# Altium schematic sheet Extensometer_Interface.SchDoc(Extensometer_Interface)
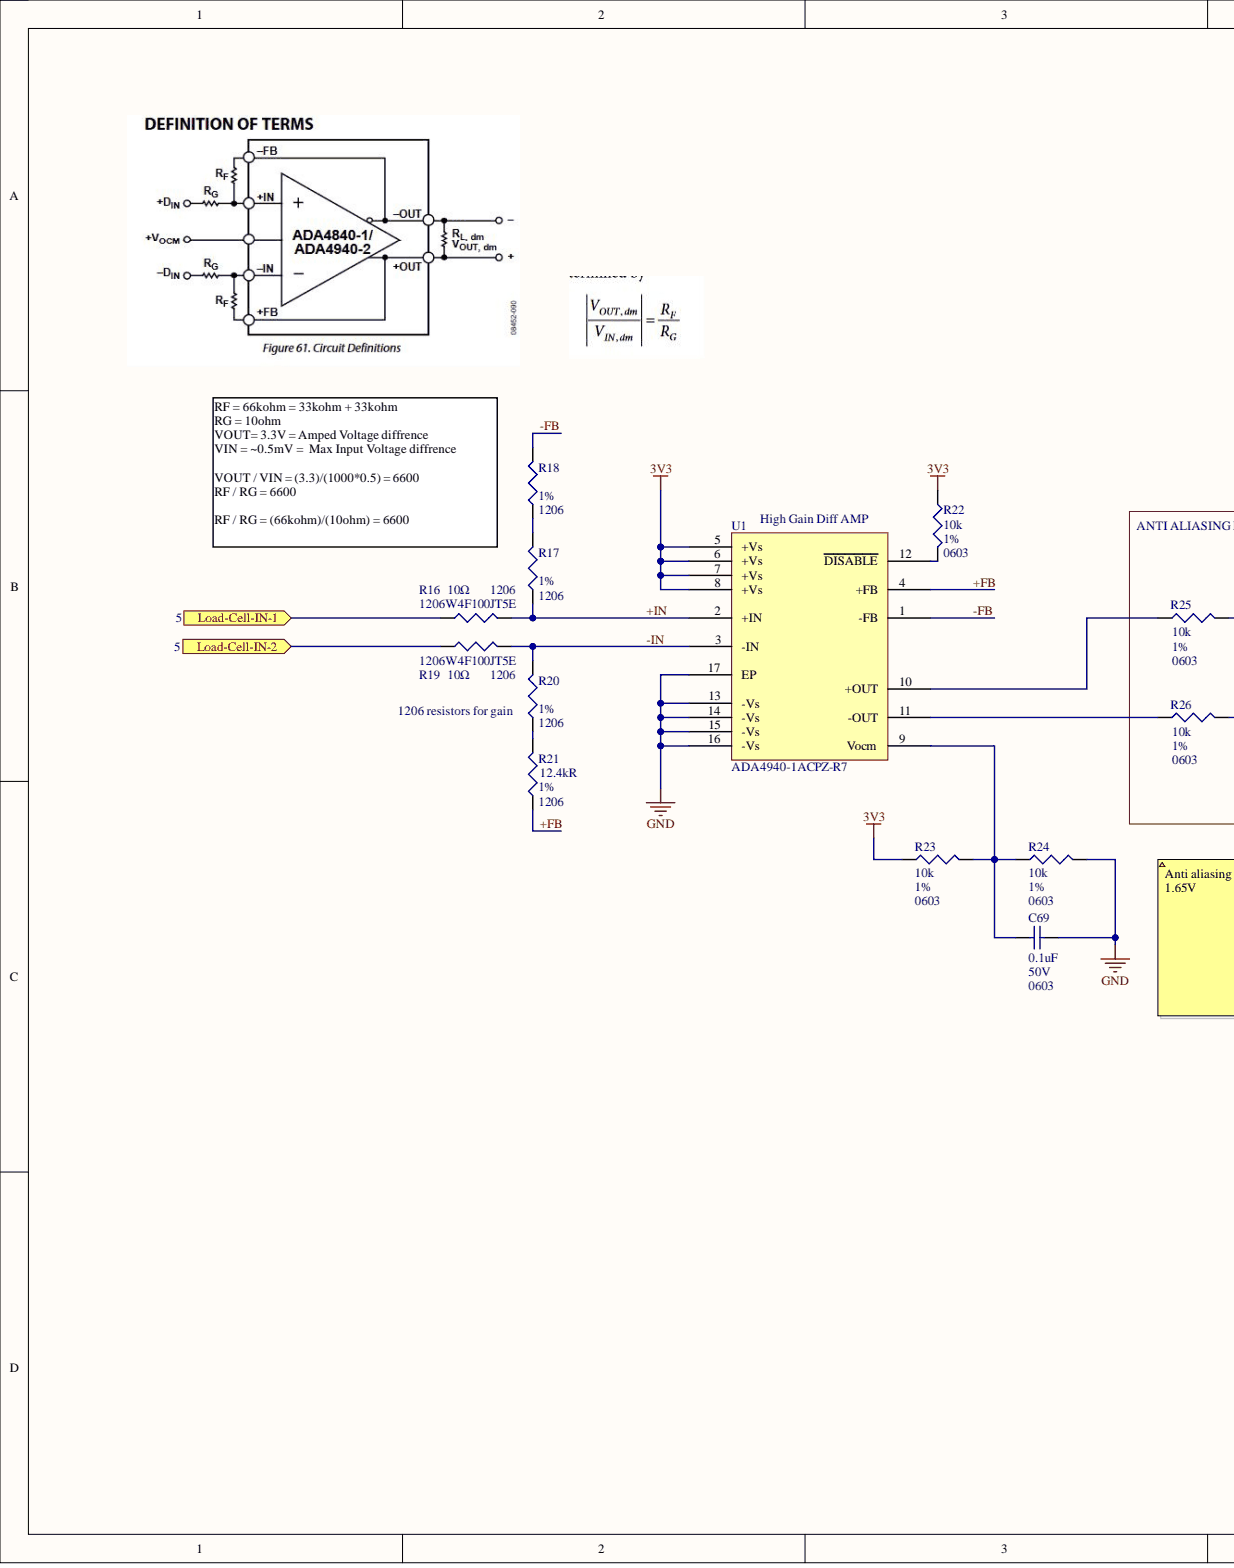
[diagram p0: Extensometer_Interface.SchDoc, left]
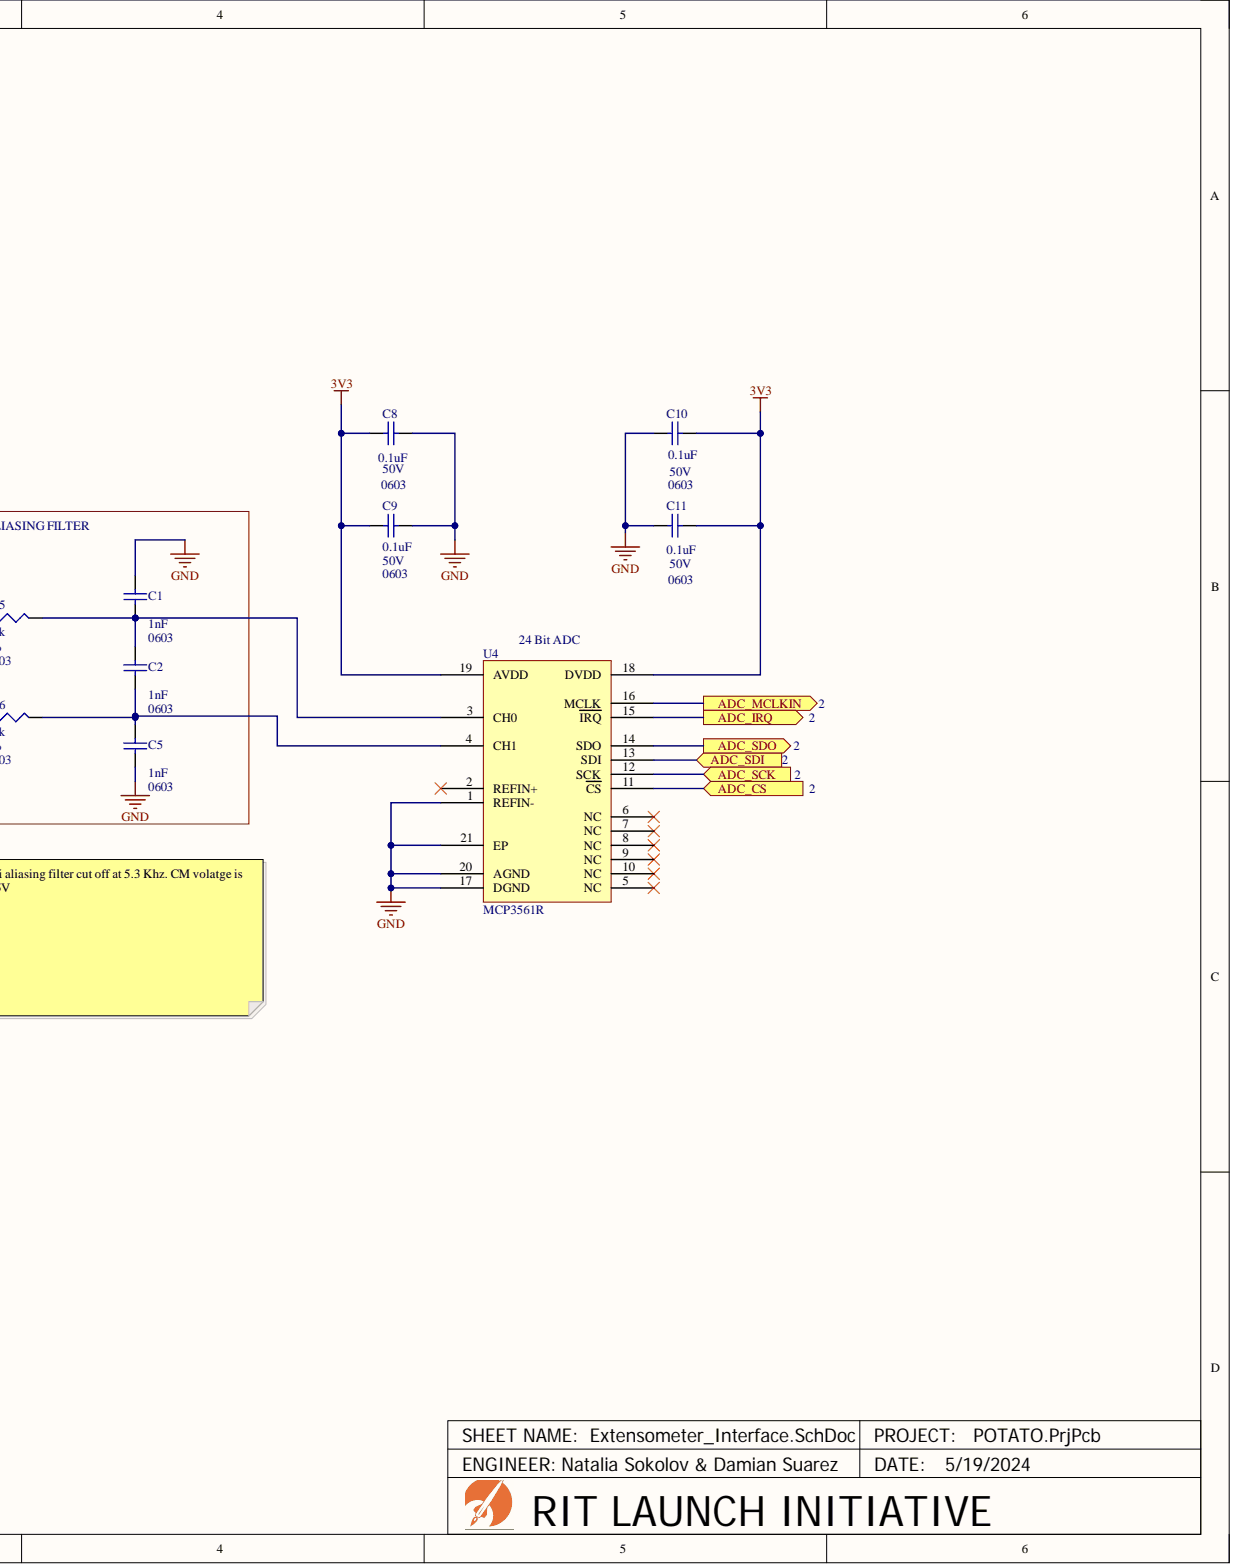
[diagram p1: Extensometer_Interface.SchDoc, right]

## Parts
C1  Capacitance: 1nF  (hidden comment: CL10B102KB8NNNC)
C2  Capacitance: 1nF  Case/Package: 0603  (hidden comment: CL10B102KB8NNNC)
C5  Capacitance: 1nF  Case/Package: 0603  (hidden comment: CL10B102KB8NNNC)
C8  Capacitance: 0.1uF  Voltage: 50V  (hidden comment: CC0603KRX7R9BB104)
C9  Capacitance: 0.1uF  Case Code (Imperial): 0603  Voltage: 50V  (hidden comment: CC0603KRX7R9BB104)
C10  Capacitance: 0.1uF  Voltage: 50V  (hidden comment: CC0603KRX7R9BB104)
C11  Capacitance: 0.1uF  Case Code (Imperial): 0603  Voltage: 50V  (hidden comment: CC0603KRX7R9BB104)
C69  Capacitance: 0.1uF  Case Code (Imperial): 0603  Voltage: 50V  (hidden comment: CC0603KRX7R9BB104)
R16  1206W4F100JT5E  Resistance: 10Ω
R17  Case/Package: 1206  Tolerance: 1%  (hidden comment: RNCP1206FTD12K4)
R18  Case/Package: 1206  Tolerance: 1%  (hidden comment: RNCP1206FTD12K4)
R19  1206W4F100JT5E  Case/Package: 1206  Resistance: 10Ω
R20  Case/Package: 1206  Tolerance: 1%  (hidden comment: RNCP1206FTD12K4)
R21  value 12.4kR  Case/Package: 1206  Tolerance: 1%  (hidden comment: RNCP1206FTD12K4)
R22  Case/Package: 0603  Resistance: 10k  Tolerance: 1%  (hidden comment: 0603WAF1002T5E)
R23  Case/Package: 0603  Resistance: 10k  Tolerance: 1%  (hidden comment: 0603WAF1002T5E)
R24  Resistance: 10k  Tolerance: 1%  (hidden comment: 0603WAF1002T5E)
R25  Case/Package: 0603  Resistance: 10k  Tolerance: 1%  (hidden comment: 0603WAF1002T5E)
R26  Case/Package: 0603  Resistance: 10k  Tolerance: 1%  (hidden comment: 0603WAF1002T5E)
U1  ADA4940-1ACPZ-R7
U4  MCP3561R

## Ports
ADC_CS, ADC_IRQ, ADC_MCLKIN, ADC_SCK, ADC_SDI, ADC_SDO, Load-Cell-IN-1, Load-Cell-IN-2

## Nets
3V3: C8.1 C9.1 C10.2 C11.2 R22.1 R23.1 U1.5(+Vs) U1.6(+Vs) U1.7(+Vs) U1.8(+Vs) U4.18(DVDD) U4.19(AVDD)
GND: C1.2 C5.1 C8.2 C9.2 C10.1 C11.1 C69.1 R24.2 U1.13(-Vs) U1.14(-Vs) U1.15(-Vs) U1.16(-Vs) U1.17(EP) U4.1(REFIN-) U4.17(DGND) U4.20(AGND) U4.21(EP)
+FB: R21.1 U1.4(+FB)
+IN: R16.2 R17.1 U1.2(+IN)
-FB: R18.2 U1.1(-FB)
-IN: R19.2 R20.2 U1.3(-IN)
ADC_CS: U4.11(CS) ; port ADC_CS
ADC_IRQ: U4.15(IRQ) ; port ADC_IRQ
ADC_MCLKIN: U4.16(MCLK) ; port ADC_MCLKIN
ADC_SCK: U4.12(SCK) ; port ADC_SCK
ADC_SDI: U4.13(SDI) ; port ADC_SDI
ADC_SDO: U4.14(SDO) ; port ADC_SDO
Load-Cell-IN-1: R16.1 ; port Load-Cell-IN-1
Load-Cell-IN-2: R19.1 ; port Load-Cell-IN-2
NetC1_1: C1.1 C2.2 R25.2 U4.3(CH0)
NetC2_1: C2.1 C5.2 R26.2 U4.4(CH1)
NetC69_2: C69.2 R23.2 R24.1 U1.9(Vocm)
NetR17_2: R17.2 R18.1
NetR20_1: R20.1 R21.2
NetR22_2: R22.2 U1.12(DISABLE)
NetR25_1: R25.1 U1.10(+OUT)
NetR26_1: R26.1 U1.11(-OUT)

Not connected: U4 2(REFIN+) 5(NC) 6(NC) 7(NC) 8(NC) 9(NC) 10(NC)

## Library data of the parts
C1 C2 C5 = CMP-001-0398-1: Ceramic Capacitor, Multilayer, Ceramic, 50V, 10% +Tol, 10% -Tol, X7R, 15% TC, 0.001uF, Surface Mount, 0603; Multilayer: Yes; Capacitor Type: Ceramic; Component Kind: Standard; Dielectric Material: Ceramic; Height: 0.9mm; JESD-609 Code: e3; JLCPCB Part #: C1588; Length: 1.6mm; Max Operating Temperature: 125°C; Min Operating Temperature: -55°C; Mounting Technology: Surface Mount; Package Shape: Rectangular; Package Style: SMTMeter; Packaging: Tape and Reel; Pins: 2; Rated DC Voltage (URdc): 50V; Reach SVHC Compliant: Yes; RoHS Compliant: Yes; Temperature Characteristics Code: X7R; Terminal Finish: Nickel; Termination: Wraparound; Tolerance  (fill in as +-): 10%; Tolerance (fill in as +-): 10%; Width: 0.8mm; Library Name: RIT Launch Initiative
  C1: Case/Package: 0603
C8-C11 C69 = CMP-001-0242-3: CAP CER 0.1UF 50V X7R 0603; Dielectric Material: Ceramic; Tolerance: 10%; Case Code (Metric): 1608; Case/Package: 0603; Component Kind: Standard; Depth: 0.8mm; Dielectric: X7R; Halogen Free: Halogen Free; Height: 0.8mm; JLCPCB Part #: C14663; Lead Free: Lead Free; Length: 0.063inch; Max Operating Temperature: 125°C; Min Operating Temperature: -55°C; Mount: Surface Mount; Number of Pins: 2; Package Quantity: 1; Packaging: Tape and Reel; Radiation Hardening: No; REACH SVHC: No SVHC; RoHS Compliant: Yes; Series: CC; Termination: SMD/SMT; Thickness: 0.035inch; Voltage Rating: 50V; Width: 0.031inch; Library Name: RIT Launch Initiative
  C8 C10: Case Code (Imperial): 0603
R16 R19 = CMP-001-0406-1: Component Kind: Standard; Description: 1206W4F100JT5E; JLCPCB Part #: C17903; Library Name: RIT Launch Initiative
  R16: Case/Package: 1206
R17 R18 R20 = CMP-001-0412-3: Fixed Resistor, Thin Film, 0.5W, 12400ohm, 200V, 1% +/-Tol, 100ppm/Cel, Surface Mount, 1206; Manufacturer Series: VSMP; Additional Feature: ANTI-SULFUR; Package Height: 0.5mm; Package Length: 3.1mm; Package Width: 1.5mm; Power: 500mW; Rated Temperature: 70°C; Temperature Coefficient: 100ppm/°C; Value: 12.4kR; Component Kind: Standard; Construction: Chip; JESD-609 Code: e3; JLCPCB Part #: C2481788; Max Operating Temperature: 155°C; Min Operating Temperature: -55°C; Mounting Technology: Surface Mount; Package Shape: Rectangular; Package Style: SMTMeter; Packaging: Tape and Reel; Pins: 2; Reach SVHC Compliant: Yes; Resistor Type: FIXED RESISTOR; RoHS Compliant: Yes; Series: VSMP; Technology: THIN FILM; Terminal Finish: Nickel; Termination: Wraparound; Working Voltage: 200V; Library Name: RIT Launch Initiative
R21 = CMP-001-0412-3: Fixed Resistor, Thin Film, 0.5W, 12400ohm, 200V, 1% +/-Tol, 100ppm/Cel, Surface Mount, 1206; Manufacturer Series: VSMP; Additional Feature: ANTI-SULFUR; Package Height: 0.5mm; Package Length: 3.1mm; Package Width: 1.5mm; Power: 500mW; Rated Temperature: 70°C; Temperature Coefficient: 100ppm/°C; Component Kind: Standard; Construction: Chip; JESD-609 Code: e3; JLCPCB Part #: C2481788; Max Operating Temperature: 155°C; Min Operating Temperature: -55°C; Mounting Technology: Surface Mount; Package Shape: Rectangular; Package Style: SMTMeter; Packaging: Tape and Reel; Pins: 2; Reach SVHC Compliant: Yes; Resistor Type: FIXED RESISTOR; RoHS Compliant: Yes; Series: VSMP; Technology: THIN FILM; Terminal Finish: Nickel; Termination: Wraparound; Working Voltage: 200V; Library Name: RIT Launch Initiative
R22-R26 = CMP-001-0268-1: RES 10K OHM 1% 1/10W 0603; Component Kind: Standard; JLCPCB Part #: C25804; RoHS Compliant: Yes; Library Name: RIT Launch Initiative
  R24: Case/Package: 0603
U1 = CMP-001-0372-1: Operational Amplifier, 1 Func, 350uV Offset-Max, BIPolar; Average Bias Current-Max (IIB): 7uA; Channels: 1; Common-mode Reject Ratio-Nom: 119dB; Nominal Supply Voltage: 5V; Package Equivalence Code: LCC16,.12SQ,20; Qualification Status: Not Qualified; Slew Rate: 95V/µs; Supply Voltage Limit-Max: 8V; Amplifier Type: OPERATIONAL AMPLIFIER; Case/Package: HVQCCN; Component Kind: Standard; Height - Seated (Max): 0.8mm; Input Offset Voltage-Max: 350uV; JESD-30 Code: S-XQCC-N16; JESD-609 Code: e3; JLCPCB Part #: C468516; Length: 3mm; Max Operating Temperature: 125°C; Min Operating Temperature: -40°C; Moisture Sensitivity Level: 3; Package Shape: Square; Package Style: CHIP CARRIER, HEAT SINK/SLUG, VERY THIN PROFILEMeter; Pins: 16; Power Supplies: 5V; Reach SVHC Compliant: Yes; RoHS Compliant: Yes; Surface Mount: Yes; Technology: BIPOLAR; Temperature Grade: AUTOMOTIVE; Terminal Finish: Tin; Terminal Form: No Lead; Terminal Pitch: 0.5mm; Terminal Position: QUAD; Width: 3mm; Library Name: RIT Launch Initiative
U4 = CMP-001-0373-8: ADC, Delta-Sigma, 24-Bit, 1 Func, 1 Channel, Serial Access, PDSO20; Component Kind: Standard; Data SHeet: https://ww1.microchip.com/downloads/en/DeviceDoc/MCP3561.2.4R-Data-Sheet-DS200006391A.pdf; RoHS Compliant: Yes; Library Name: RIT Launch Initiative
